# Altium schematic sheet Sheet1.SchDoc
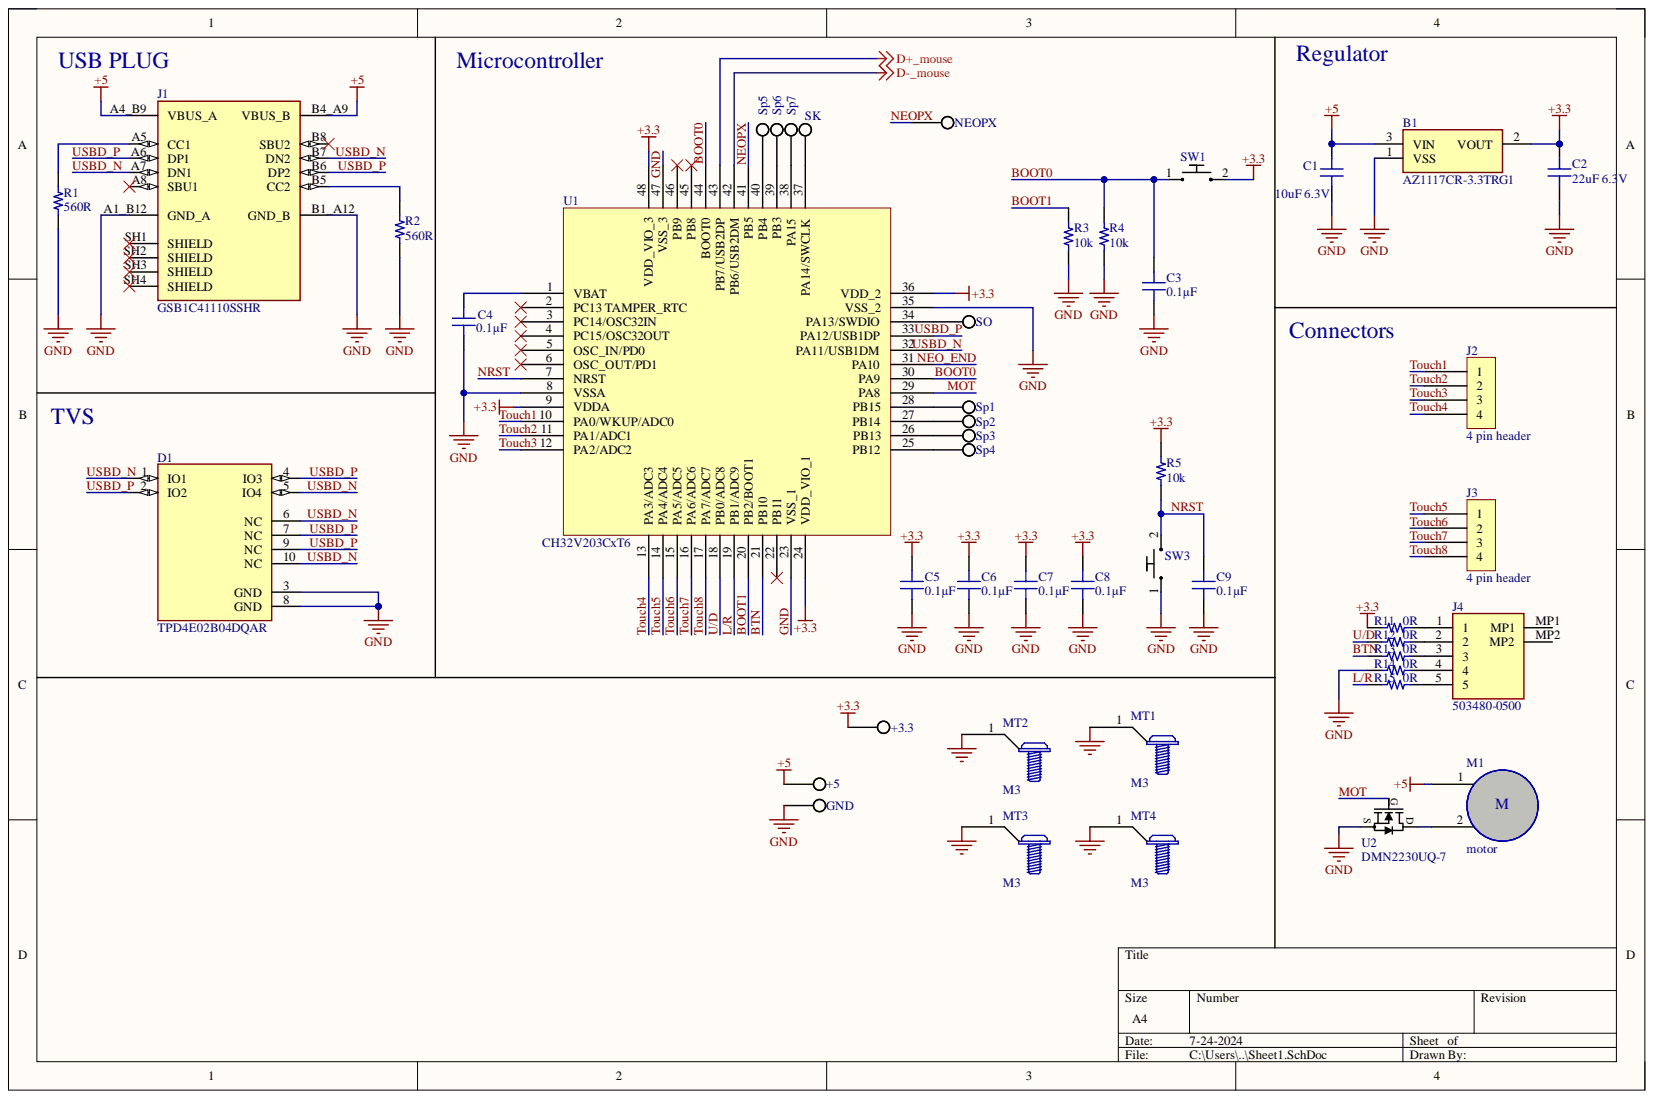
[diagram p0: Sheet1.SchDoc]

## Parts
+3.3  TestPoint  [TP2020]  (value not drawn)
+5  TestPoint  [TP2020]  (value not drawn)
B1  AZ1117CR-3.3TRG1  [SOT89-3]
C1  10uF 6.3V  value 10uF  Rated Voltage: 6.3V  [CAP 0603_1608]
C2  22uF 6.3V  value 22uF  [CAP 0603_1608]
C3  0.1µF  [CAP 0603_1608]
C4  0.1µF  [CAP 0603_1608]
C5  0.1µF  [CAP 0603_1608]
C6  0.1µF  [CAP 0603_1608]
C7  0.1µF  [CAP 0603_1608]
C8  0.1µF  [CAP 0603_1608]
C9  0.1µF  [CAP 0603_1608]
D1  TPD4E02B04DQAR  [DIO_TPD4E02B04DQAR]
GND  TestPoint  [TP2020]  (value not drawn)
J1  GSB1C41110SSHR  [AMPHENOL_GSB1C41110SSHR]
J2  4 pin header  [4 pin header]
J3  4 pin header  [4 pin header]
J4  503480-0500  [5034800500]
M1  motor  [2 pin header]
MT1  M3  [M3_MT_HOLE]
MT2  M3  [M3_MT_HOLE]
MT3  M3  [M3_MT_HOLE]
MT4  M3  [M3_MT_HOLE]
NEOPX  TestPoint  [TP2020]  (value not drawn)
R1  560R  [RES 0603_1608]
R2  560R  [RES 0603_1608]
R3  10k  [RES 0603_1608]
R4  10k  [RES 0603_1608]
R5  10k  [RES 0603_1608]
R11  0R  [RES 0603_1608]
R12  0R  [RES 0603_1608]
R13  0R  [RES 0603_1608]
R14  0R  [RES 0603_1608]
R15  0R  [RES 0603_1608]
SK  TestPoint  [TP2020]  (value not drawn)
SO  TestPoint  [TP2020]  (value not drawn)
Sp1  TestPoint  [TP2020]  (value not drawn)
Sp2  TestPoint  [TP2020]  (value not drawn)
Sp3  TestPoint  [TP2020]  (value not drawn)
Sp4  TestPoint  [TP2020]  (value not drawn)
Sp5  TestPoint  [TP2020]  (value not drawn)
Sp6  TestPoint  [TP2020]  (value not drawn)
Sp7  TestPoint  [TP2020]  (value not drawn)
SW1  SPST_BUTTON  [3x4mmBTN]  (value not drawn)
SW3  SPST_BUTTON  [3x4mmBTN]  (value not drawn)
U1  CH32V203CxT6  [LQFP48]
U2  DMN2230UQ-7  [DMN2230UQ_DIO-M]

## Nets
+3.3: +3.3.TP B1.2 C2.2 C5.2 C6.2 C7.2 C8.2 R5.2 R11.1 SW1.2 U1.9(VDDA) U1.24(VDD_VIO_1) U1.36(VDD_2) U1.48(VDD_VIO_3)
+5: +5.TP B1.3 C1.2 J1.A4_B9(VBUS_A) J1.B4_A9(VBUS_B) M1.1
D+_mouse: U1.43(PB7/USB2DP)
D-_mouse: U1.42(PB6/USB2DM)
BOOT0: C3.2 R4.2 SW1.1 U1.30(PA9) U1.44(BOOT0)
BOOT1: R3.2 U1.20(PB2/BOOT1)
BTN: R13.1 U1.21(PB10)
GND: B1.1 C1.1 C2.1 C3.1 C4.1 C5.1 C6.1 C7.1 C8.1 C9.1 D1.3(GND) D1.8(GND) GND.TP J1.A1_B12(GND_A) J1.B1_A12(GND_B) MT1.1 MT2.1 MT3.1 MT4.1 R1.1 R2.1 R3.1 R4.1 R14.1 SW3.1 U1.8(VSSA) U1.23(VSS_1) U1.35(VSS_2) U1.47(VSS_3) U2.3
L/R: R15.1 U1.19(PB1/ADC9)
MOT: U1.29(PA8) U2.2
NEO_END: U1.31(PA10)
NEOPX: NEOPX.TP U1.41(PB5)
NRST: C9.2 R5.1 SW3.2 U1.7(NRST)
Touch1: J2.1 U1.10(PA0/WKUP/ADC0)
Touch2: J2.2 U1.11(PA1/ADC1)
Touch3: J2.3 U1.12(PA2/ADC2)
Touch4: J2.4 U1.13(PA3/ADC3)
Touch5: J3.1 U1.14(PA4/ADC4)
Touch6: J3.2 U1.15(PA5/ADC5)
Touch7: J3.3 U1.16(PA6/ADC6)
Touch8: J3.4 U1.17(PA7/ADC7)
U/D: R12.1 U1.18(PB0/ADC8)
USBD_N: D1.1(IO1) D1.5(IO4) D1.6(NC) D1.10(NC) J1.A7(DN1) J1.B7(DN2) U1.32(PA11/USB1DM)
USBD_P: D1.2(IO2) D1.4(IO3) D1.7(NC) D1.9(NC) J1.A6(DP1) J1.B6(DP2) U1.33(PA12/USB1DP)
NetC4_2: C4.2 U1.1(VBAT)
NetJ1_A5: J1.A5(CC1) R1.2
NetJ1_B5: J1.B5(CC2) R2.2
NetJ4_1: J4.1 R11.2
NetJ4_2: J4.2 R12.2
NetJ4_3: J4.3 R13.2
NetJ4_4: J4.4 R14.2
NetJ4_5: J4.5 R15.2
NetM1_2: M1.2 U2.1
NetSK_TP: SK.TP U1.37(PA14/SWCLK)
NetSO_TP: SO.TP U1.34(PA13/SWDIO)
NetSp1_TP: Sp1.TP U1.28(PB15)
NetSp2_TP: Sp2.TP U1.27(PB14)
NetSp3_TP: Sp3.TP U1.26(PB13)
NetSp4_TP: Sp4.TP U1.25(PB12)
NetSp5_TP: Sp5.TP U1.40(PB4)
NetSp6_TP: Sp6.TP U1.39(PB3)
NetSp7_TP: Sp7.TP U1.38(PA15)

Not connected: J1 A8(SBU1) B8(SBU2) SH1(SHIELD) SH2(SHIELD) SH3(SHIELD) SH4(SHIELD); J4 MP1 MP2; U1 2(PC13_TAMPER_RTC) 3(PC14/OSC32IN) 4(PC15/OSC32OUT) 5(OSC_IN/PD0) 6(OSC_OUT/PD1) 22(PB11) 45(PB8) 46(PB9)

## Library data of the parts
+3.3 +5 GND NEOPX SK SO Sp1-Sp7 = JMP-RTZL7I: PC TEST POINT MINIATURE; Manufacturer: Keystone Electronics; Database Table Name: Special; SCH_Comment: 5015 TestPoint; Component Kind: Standard; Part Number: JMP-RTZL7I; Supplier 1: DigiKey; Supplier Part Number 1: 36-5015TR-ND; Library Name: Database_Libs_RenaudG.DbLib
B1 = TRS-RTZP45: 3.3v LDO Voltage regulator; Manufacturer_Name: Torex; Manufacturer_Part_Number: XC6206P331MR-G; Database Table Name: ICs; Geometry.Height: 1.3mm; SCH_Comment: AZ1117CR33; Component Kind: Standard; Datasheet Link: https://www.torexsemi.com/file/xc6206/XC6206.pdf; JLC PartNumber: C460428; Mouser Part Number: 865-XC6206P331MR-G; Mouser Price/Stock: https://www.mouser.co.uk/ProductDetail/Torex-Semiconductor/XC6206P331MR-G?qs=AsjdqWjXhJ%252B7jjWBpr1yow%3D%3D; Part Number: TRS-RTZP45; Supplier 1: Digi-key; Supplier Part Number 1: AZ1117CR-3.3TRG1DICT-ND; Library Name: Database_Libs_RenaudG.DbLib
C1 = CAP0603-RU10OA: CAP CER 10uF; Manufacturer: YAGEO; Database Table Name: Passives-Cap; Tolerance: ±10%; Component Kind: Standard; JLC PartNumber: C19702; Part Number: CAP0603-RU10OA; SCH_Comment: 10uF 6.3V; Size: 0603 (1608 Metric); Supplier 1: Digi-Key; Supplier Part Number 1: 311-1781-1-ND; Library Name: Database_Libs_RenaudG.DbLib
C2 = CAP0603-SAQ85G: 6.3V 22uF X5R ±20% 0603 Multilayer Ceramic Capacitors MLCC - SMD/SMT ROHS; Manufacturer: Samsung Electro-Mechanics; Database Table Name: Passives-Cap; Component Kind: Standard; JLC PartNumber: C59461; Part Number: CAP0603-SAQ85G; Rated Voltage: 6.3V; SCH_Comment: 22uF 6.3V; Supplier 1: Digi-Key; Tolerance: ±20%; Library Name: Database_Libs_RenaudG.DbLib
C3-C9 = CAP-RTZP49: CAP CER SMD 0603 .1UF 10% X7R 25; Manufacturer: Samsung Electro-Mechanics; Database Table Name: Passives-Cap; Rated Voltage: 50V; Component Kind: Standard; JLC PartNumber: C14663; Part Number: CAP-RTZP49; SCH_Comment: 0.1µF; Size: 0603 (1608 Metric); Supplier 1: Digi-key; Supplier Part Number 1: 1276-CL10B104KB8NNNLCT-ND; Tolerance: ±10%; Value: 0.1µF; Library Name: Database_Libs_RenaudG.DbLib
D1 = DIO-RTZP4I: ESD protection diode; Database Table Name: ICs; MF: Texas Instruments; Check_prices: https://www.snapeda.com/parts/TPD4E02B04DQAR/Texas%20Instruments/view-part/576424/?ref=eda; Component Kind: Standard; Digi-Key_Part_Number: 296-43875-1-ND; JLC PartNumber: C106794; MP: TPD4E02B04DQAR; Package: USON-10 Texas Instruments; Part Number: DIO-RTZP4I; Purchase-URL: https://pricing.snapeda.com/search/part/TPD4E02B04DQAR/?ref=eda; SCH_Comment: TPD4E02B04; SnapEDA_Link: https://www.snapeda.com/parts/TPD4E02B04DQAR/Texas%20Instruments/view-part/576424/?ref=snap; Supplier 1: Digikey; Supplier Part Number 1: 296-43875-2-ND; Library Name: Database_Libs_RenaudG.DbLib
J1 = USB_16p_SMT: 16 pin usb type c right angle female connector; Manufacturer: Amphenol; Database Table Name: Connectors; MF: Amphenol Canada; SCH_Comment: USB-C; Check_prices: https://www.snapeda.com/parts/GSB1C41110SSHR/Amphenol/view-part/?ref=eda; Component Kind: Standard; MP: GSB1C41110SSHR; Package: None; Part Number: USB_16p_SMT; SnapEDA_Link: https://www.snapeda.com/parts/GSB1C41110SSHR/Amphenol/view-part/?ref=snap; Library Name: Database_Libs_RenaudG.DbLib
J2 J3 = 4PinHeader: Manufacturer: Sullins Connector Solutions; Database Table Name: Connectors; Component Kind: Standard; Description: 4 pin header; Part Number: 4PinHeader; SCH_Comment: 4 pin header; Supplier 1: Digi-Key; Supplier Part Number 1: S7002-ND; Library Name: Database_Libs_RenaudG.DbLib
J4 = 503480-0500: Connector; Manufacturer_Name: Molex; Component Kind: Standard; Height: 1.3mm; Manufacturer_Part_Number: 503480-0500; Mouser Part Number: 538-503480-0500; Mouser Price/Stock: https://www.mouser.co.uk/ProductDetail/Molex/503480-0500?qs=gt1LBUVyoHk%2Fe71glqHWvw%3D%3D
M1 = VibrationMotor: Vibrating motor; Manufacturer: ChingChong; Database Table Name: Special; SCH_Comment: Vibrator; Component Kind: Standard; Part Number: VibrationMotor; Library Name: Database_Libs_RenaudG.DbLib
MT1-MT4 = NSP-M3: M3 pounting screw; Database Table Name: Special; Component Kind: Standard; Part Number: NSP-M3; SCH_Comment: M3; Library Name: Database_Libs_RenaudG.DbLib
R1 R2 = RES-RTZP5N: RES SMD 560 OHM 1% 1/10W 0603; Manufacturer: Panasonic Electronic Components; Database Table Name: Passives-Res; Power (Watts): 0.1W, 1/10W; Temperature Coefficient: ±100ppm/°C; Value: 560; Component Kind: Standard; Composition: Thick Film; Features: Automotive AEC-Q200; JLC PartNumber: C23204; Operating Temperature: -55°C ~ 155°C; Part Number: RES-RTZP5N; SCH_Comment: 560R; Size: 0603 (1608 Metric); Supplier 1: Digi-key; Supplier Part Number 1: P560HCT-ND; Tolerance: ±1%; Library Name: Database_Libs_RenaudG.DbLib
R3-R5 = RES-RTZP5R: RES SMD 10K OHM 1% 1/10W 0603; Manufacturer: Panasonic Electronic Components; Database Table Name: Passives-Res; Power (Watts): 0.1W, 1/10W; Temperature Coefficient: ±100ppm/°C; Component Kind: Standard; Composition: Thick Film; Features: Automotive AEC-Q200; JLC PartNumber: C25804; Operating Temperature: -55°C ~ 155°C; Part Number: RES-RTZP5R; SCH_Comment: 10k; Size: 0603 (1608 Metric); Supplier 1: Digi-key; Supplier Part Number 1: P10.0KHCT-ND; Tolerance: ±1%; Value: 10k; Library Name: Database_Libs_RenaudG.DbLib
R11-R15 = ERJ-3GEY0R00V: RES SMD 0 OHM JUMPER 1/10W 0603; Manufacturer: Panasonic Electronic Components; Database Table Name: Passives-Res; Temperature Coefficient: ±200ppm/°C; Value: 0; Component Kind: Standard; Features: Automotive AEC-Q200; Operating Temperature: -55°C ~ 155°C; Part Number: ERJ-3GEY0R00V; Power (Watts): 1/10W; SCH_Comment: 0R; Size: 0603 (1608 Metric); Supplier 1: Digi-key; Supplier Part Number 1: P0.0GCT-ND; Tolerance: --; Library Name: Database_Libs_RenaudG.DbLib
SW1 SW3 = BTN-000001: 50mA Brick nogging SPST 12V SMD,3x4mm Tactile Switches ROHS; Manufacturer: XUNPU  XUNPU; Database Table Name: Special; SCH_Comment: Button; Component Kind: Standard; JLC PartNumber: C455280; Part Number: BTN-000001; Supplier 1: DigiKey; Supplier Part Number 1: 2449-CS1213AGF160DKR-ND; Library Name: Database_Libs_RenaudG.DbLib
U1 = MCU-RV4Q62: 32bit RISC-V MCU 144MHz; Manufacturer: WCH-IC; Database Table Name: ICs; Component Kind: Standard; JLC PartNumber: C3001172; Part Number: MCU-RV4Q62; SCH_Comment: CH32V203CxT6; Supplier 1: Alibaba; Supplier Part Number 1: CH32V203C8T6; Library Name: Database_Libs_RenaudG.DbLib
U2 = DMN2230UQ-7: NPN mosfet; Mfr_Name: Diodes Inc; Database Table Name: ICs; SCH_Comment: Mosfet N; Component Kind: Standard; Part Number: DMN2230UQ-7; Library Name: Database_Libs_RenaudG.DbLib
